# Altium schematic sheet LINBUS.SchDoc
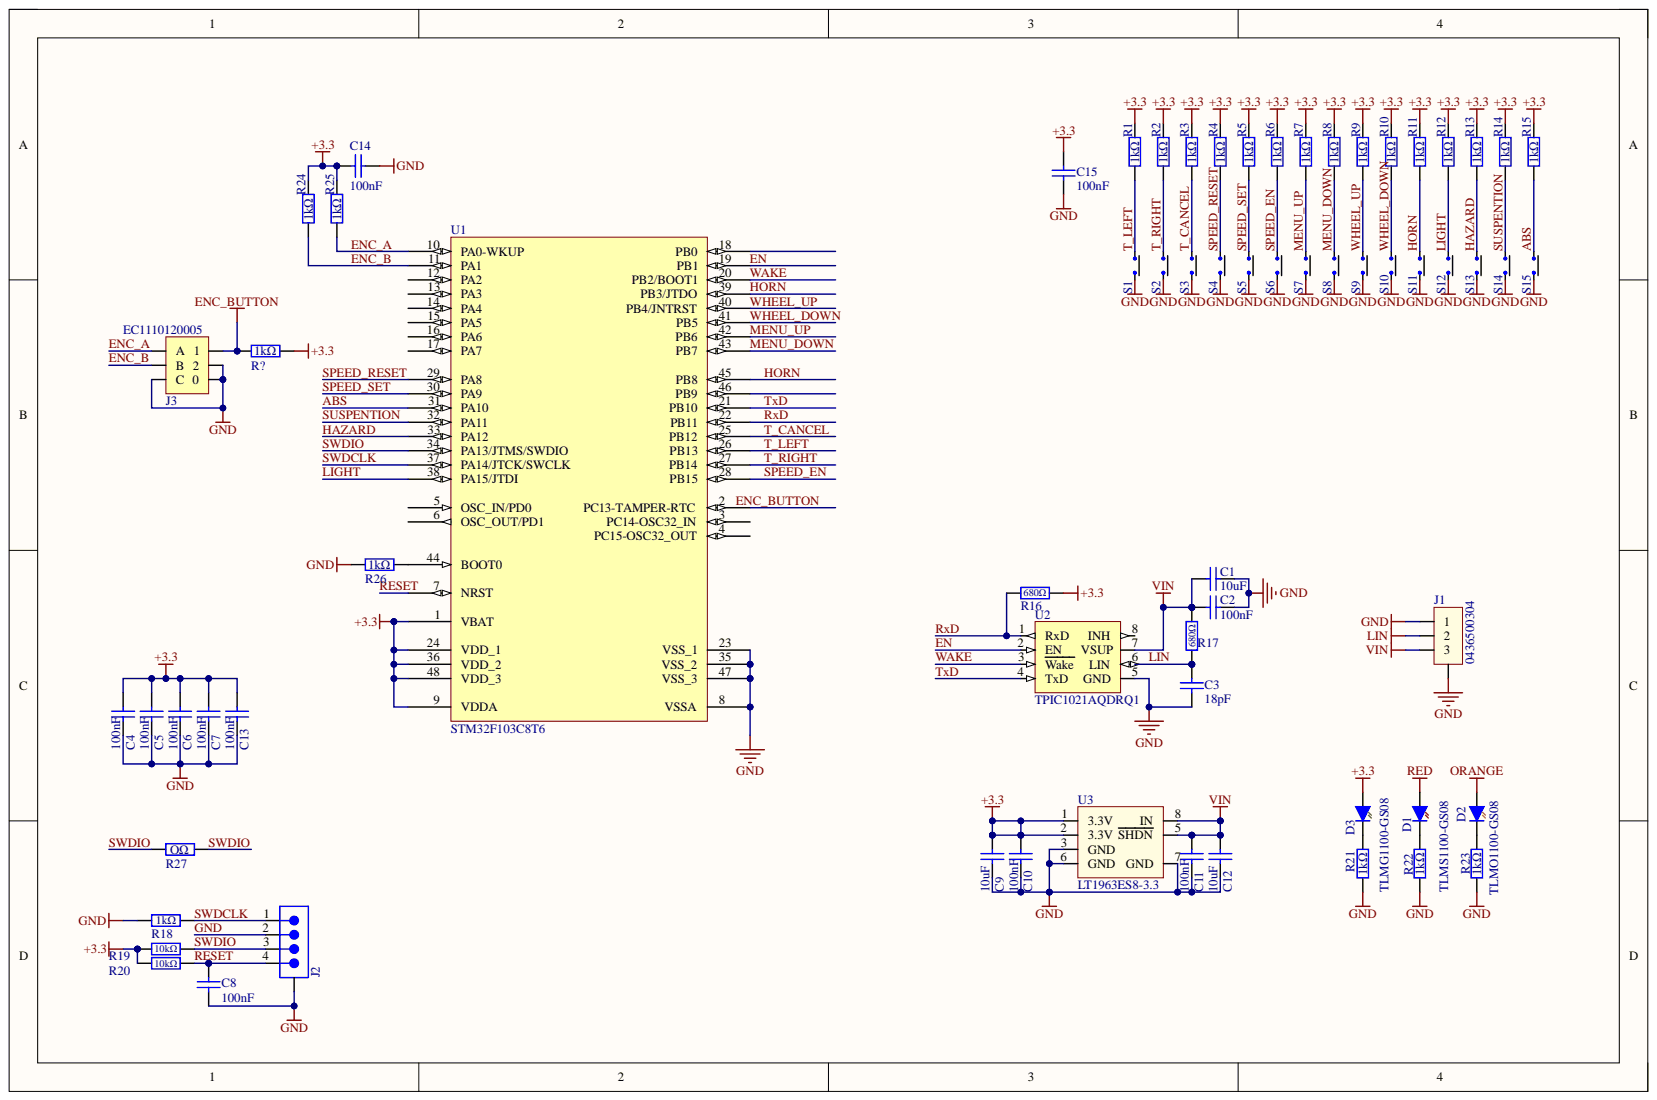
[diagram p0: LINBUS.SchDoc]

## Parts
C1  10uF  [C0603_H]
C2  100nF  [C0603_M]
C3  18pF  [C0603_L]
C4  100nF  [C0603_M]
C5  100nF  [C0603_M]
C6  100nF  [C0603_M]
C7  100nF  [C0603_M]
C8  100nF  [C0603_M]
C9  10uF  [C0603_H]
C10  100nF  [C0603_M]
C11  100nF  [C0603_M]
C12  10uF  [C0603_H]
C13  100nF  [C0603_M]
C14  100nF  [C0603_M]
C15  100nF  [C0603_M]
D1  TLMS1100-GS08  [TLMS1100]
D2  TLMO1100-GS08  [TLMO1100]
D3  TLMG1100-GS08  [TLMG1100]
J1  0436500304  [0436500304]
J2  338068-4  [338068-4]  (value not drawn)
J3  EC1110120005  [EC1110120005]
R1  1k 1%  [R0603_1k]  (value not drawn)
R2  1k 1%  [R0603_1k]  (value not drawn)
R3  1k 1%  [R0603_1k]  (value not drawn)
R4  1k 1%  [R0603_1k]  (value not drawn)
R5  1k 1%  [R0603_1k]  (value not drawn)
R6  1k 1%  [R0603_1k]  (value not drawn)
R7  1k 1%  [R0603_1k]  (value not drawn)
R8  1k 1%  [R0603_1k]  (value not drawn)
R9  1k 1%  [R0603_1k]  (value not drawn)
R10  1k 1%  [R0603_1k]  (value not drawn)
R11  1k 1%  [R0603_1k]  (value not drawn)
R12  1k 1%  [R0603_1k]  (value not drawn)
R13  1k 1%  [R0603_1k]  (value not drawn)
R14  1k 1%  [R0603_1k]  (value not drawn)
R15  1k 1%  [R0603_1k]  (value not drawn)
R16  680R 1%  [R0603_680R]  (value not drawn)
R17  680R 1%  [R0603_680R]
R18  1k 1%  [R0402_1K]  (value not drawn)
R19  10k 1%  [R0603_10k]
R20  10k 1%  [R0603_10k]
R21  1k 1%  [R0603_1k]  (value not drawn)
R22  1k 1%  [R0603_1k]  (value not drawn)
R23  1k 1%  [R0603_1k]  (value not drawn)
R24  1k 1%  [R0603_1k]  (value not drawn)
R25  1k 1%  [R0603_1k]  (value not drawn)
R26  1k 1%  [R0603_1k]  (value not drawn)
R27  0R  [R0603_0R]  (value not drawn)
R?  1k 1%  [R0603_1k]  (value not drawn)
S1  EVQP9P02W  [EVQP9P02W]  (value not drawn)
S2  EVQP9P02W  [EVQP9P02W]  (value not drawn)
S3  EVQP9P02W  [EVQP9P02W]  (value not drawn)
S4  EVQP9P02W  [EVQP9P02W]  (value not drawn)
S5  EVQP9P02W  [EVQP9P02W]  (value not drawn)
S6  EVQP9P02W  [EVQP9P02W]  (value not drawn)
S7  EVQP9P02W  [EVQP9P02W]  (value not drawn)
S8  EVQP9P02W  [EVQP9P02W]  (value not drawn)
S9  EVQP9P02W  [EVQP9P02W]  (value not drawn)
S10  EVQP9P02W  [EVQP9P02W]  (value not drawn)
S11  EVQP9P02W  [EVQP9P02W]  (value not drawn)
S12  EVQP9P02W  [EVQP9P02W]  (value not drawn)
S13  EVQP9P02W  [EVQP9P02W]  (value not drawn)
S14  EVQP9P02W  [EVQP9P02W]  (value not drawn)
S15  EVQP9P02W  [EVQP9P02W]  (value not drawn)
U1  STM32F103C8T6  [LQFP48]
U2  TPIC1021AQDRQ1  [SO8]
U3  LT1963ES8-3.3  [SO8]

## Nets
+3.3: C4.2 C5.2 C6.2 C7.2 C9.2 C10.2 C13.2 C14.2 C15.1 D3.1 R1.2 R2.2 R3.2 R4.2 R5.2 R6.2 R7.2 R8.2 R9.2 R10.2 R11.2 R12.2 R13.2 R14.2 R15.2 R16.2 R19.1 R20.1 R24.2 R25.2 R?.2 U1.1(VBAT) U1.9(VDDA) U1.24(VDD_1) U1.36(VDD_2) U1.48(VDD_3) U3.1(3.3V) U3.2(3.3V)
ORANGE: D2.1
RED: D1.1
VIN: C1.1 C2.2 C11.2 C12.2 J1.3 R17.2 U2.7(VSUP) U3.5(SHDN) U3.8(IN)
ABS: R15.1 S15.1 U1.31(PA10)
EN: U1.19(PB1) U2.2(EN)
ENC_A: J3.A R25.1 U1.10(PA0-WKUP)
ENC_B: J3.B R24.1 U1.11(PA1)
ENC_BUTTON: J3.1 R?.1
GND: C1.2 C2.1 C3.2 C4.1 C5.1 C6.1 C7.1 C8.1 C9.1 C10.1 C11.1 C12.1 C13.1 C14.1 C15.2 J1.0 J1.1 J2.2 J2.5 J3.0 J3.2 J3.C R18.1 R21.1 R22.1 R23.1 R26.1 S1.2 S2.2 S3.2 S4.2 S5.2 S6.2 S7.2 S8.2 S9.2 S10.2 S11.2 S12.2 S13.2 S14.2 S15.2 U1.8(VSSA) U1.23(VSS_1) U1.35(VSS_2) U1.47(VSS_3) U2.5(GND) U3.3(GND) U3.6(GND) U3.7(GND)
HAZARD: R13.1 S13.1 U1.33(PA12)
HORN: R11.1 S11.1 U1.39(PB3/JTDO) U1.45(PB8)
LIGHT: R12.1 S12.1 U1.38(PA15/JTDI)
LIN: C3.1 J1.2 R17.1 U2.6(LIN)
MENU_DOWN: R8.1 S8.1 U1.43(PB7)
MENU_UP: R7.1 S7.1 U1.42(PB6)
RESET: C8.2 J2.4 R20.2 U1.7(NRST)
RxD: R16.1 U1.22(PB11) U2.1(RxD)
SPEED_EN: R6.1 S6.1 U1.28(PB15)
SPEED_RESET: R4.1 S4.1 U1.29(PA8)
SPEED_SET: R5.1 S5.1 U1.30(PA9)
SUSPENTION: R14.1 S14.1 U1.32(PA11)
SWDCLK: J2.1 R18.2 U1.37(PA14/JTCK/SWCLK)
SWDIO: J2.3 R19.2 R27.1 R27.2 U1.34(PA13/JTMS/SWDIO)
T_CANCEL: R3.1 S3.1 U1.25(PB12)
T_LEFT: R1.1 S1.1 U1.26(PB13)
T_RIGHT: R2.1 S2.1 U1.27(PB14)
TxD: U1.21(PB10) U2.4(TxD)
WAKE: U1.20(PB2/BOOT1) U2.3(Wake)
WHEEL_DOWN: R10.1 S10.1 U1.41(PB5)
WHEEL_UP: R9.1 S9.1 U1.40(PB4/JNTRST)
NetD1_2: D1.2 R22.2
NetD2_2: D2.2 R23.2
NetD3_2: D3.2 R21.2
NetR26_2: R26.2 U1.44(BOOT0)

Not connected: U1 2(PC13-TAMPER-RTC) 3(PC14-OSC32_IN) 4(PC15-OSC32_OUT) 5(OSC_IN/PD0) 6(OSC_OUT/PD1) 12(PA2) 13(PA3) 14(PA4) 15(PA5) 16(PA6) 17(PA7) 18(PB0) 46(PB9); U2 8(INH)

## Library data of the parts
C1 C9 C12 = Capacitor 0603 10uF 25V: 10uF 25V 0603 X5R; Voltage: 10V; Component Kind: Standard; material: X5R; Library Name: Altium_LK.SchLib
C2 C4-C8 C10 C11 C13-C15 = Capacitor 0603 100nF 50V: 100nF 50V 0603; material: X5R; Component Kind: Standard; Voltage: 50V; Library Name: Altium_LK.SchLib
C3 = Capacitor 0603 18pF 50V: 18pF 50V 0603 NPO; material: X5R; Component Kind: Standard; Voltage: 50V; Library Name: Altium_LK.SchLib
D1 = TLMS1100-GS08: Vishay RED LED 0603; Component Kind: Standard; Library Name: Altium_LK.SchLib
D2 = TLMO1100-GS08: Vishay ORANGE LED 0603; Component Kind: Standard; Library Name: Altium_LK.SchLib
D3 = TLMG1100-GS08: Vishay GREEN LED 0603; Component Kind: Standard; Library Name: Altium_LK.SchLib
J1 = 0436500304: Connector 3 pin Molex TH; Component Kind: Standard; Library Name: Altium_LK.SchLib
J2 = 338068-4: Micromatch 4 pin TH with Lock; System: Connector; Component Kind: Standard; Supplier 1: Farnell; Supplier Part Number 1: 2420396; Library Name: Altium_LK.SchLib
J3 = EC1110120005: Encoder TH; Component Kind: Standard; Library Name: Altium_LK.SchLib
R1-R15 R21-R26 R? = Resistor 0603 1k 1%: SMD Resistor; Component Kind: Standard; Library Name: Altium_LK.SchLib
R16 R17 = Resistor 0603 680R 1%: SMD Resistor; Component Kind: Standard; Library Name: Altium_LK.SchLib
R18 = Resistor 0402 1k 1%: 0402 Resistor; Component Kind: Standard; Library Name: Altium_LK.SchLib
R19 R20 = Resistor 0603 10k 1%: SMD Resistor; Component Kind: Standard; Library Name: Altium_LK.SchLib
R27 = Resistor 0603 0R: SMD Resistor Jumper; Component Kind: Standard; Library Name: Altium_LK.SchLib
S1-S15 = EVQP9P02W: Button EVQP9P02W; Component Kind: Standard; Library Name: Altium_LK.SchLib
U1 = STM32F103C8T6: ARM Cortex M3; Component Kind: Standard; Library Name: Altium_LK.SchLib
U2 = TPIC1021AQDRQ1: LIN Transceiver, 20 Kbaud, 7V to 27V Supply, 5.5V/3.5mA Output, SOIC-8; Component Kind: Standard; Library Name: Altium_LK.SchLib
U3 = LT1963ES8-3.3: 3.3V 1.5A LDO; Component Kind: Standard; Library Name: Altium_LK.SchLib
